# Altium schematic sheet [12] - HDMI, HDMI OUTPUT.SchDoc("[12] - HDMI, HDMI OUTPUT")
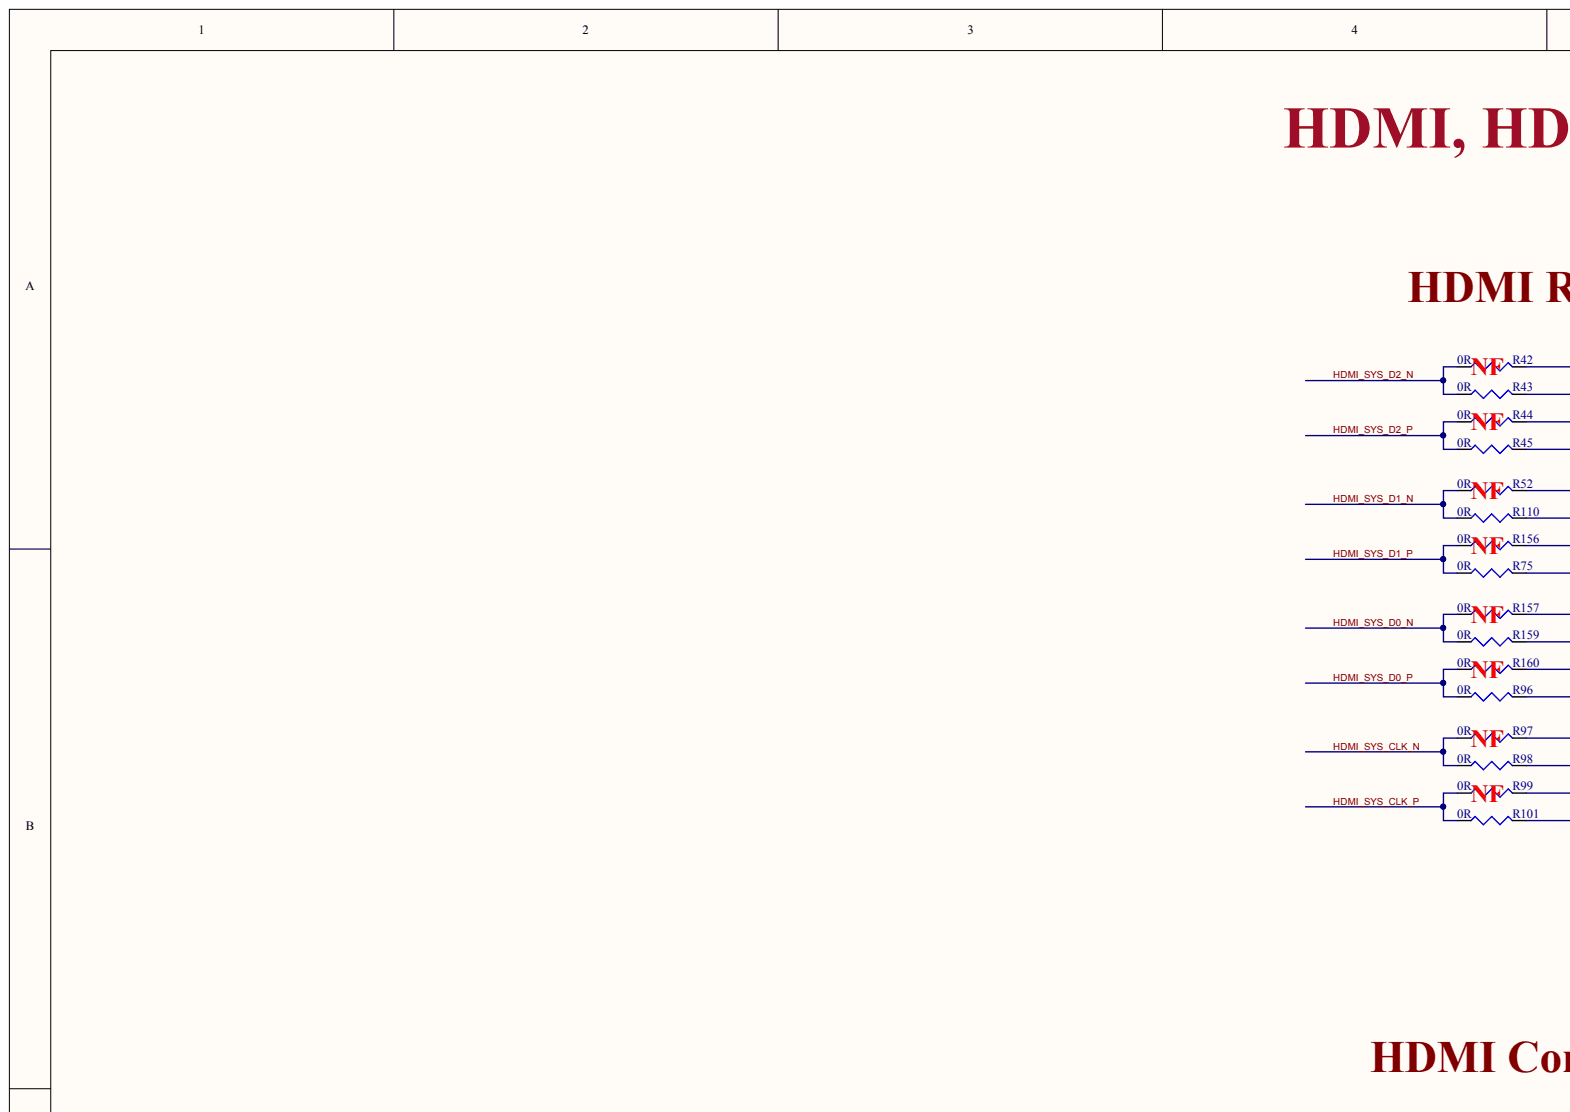
[diagram p0: [12] - HDMI, HDMI OUTPUT.SchDoc, top left]
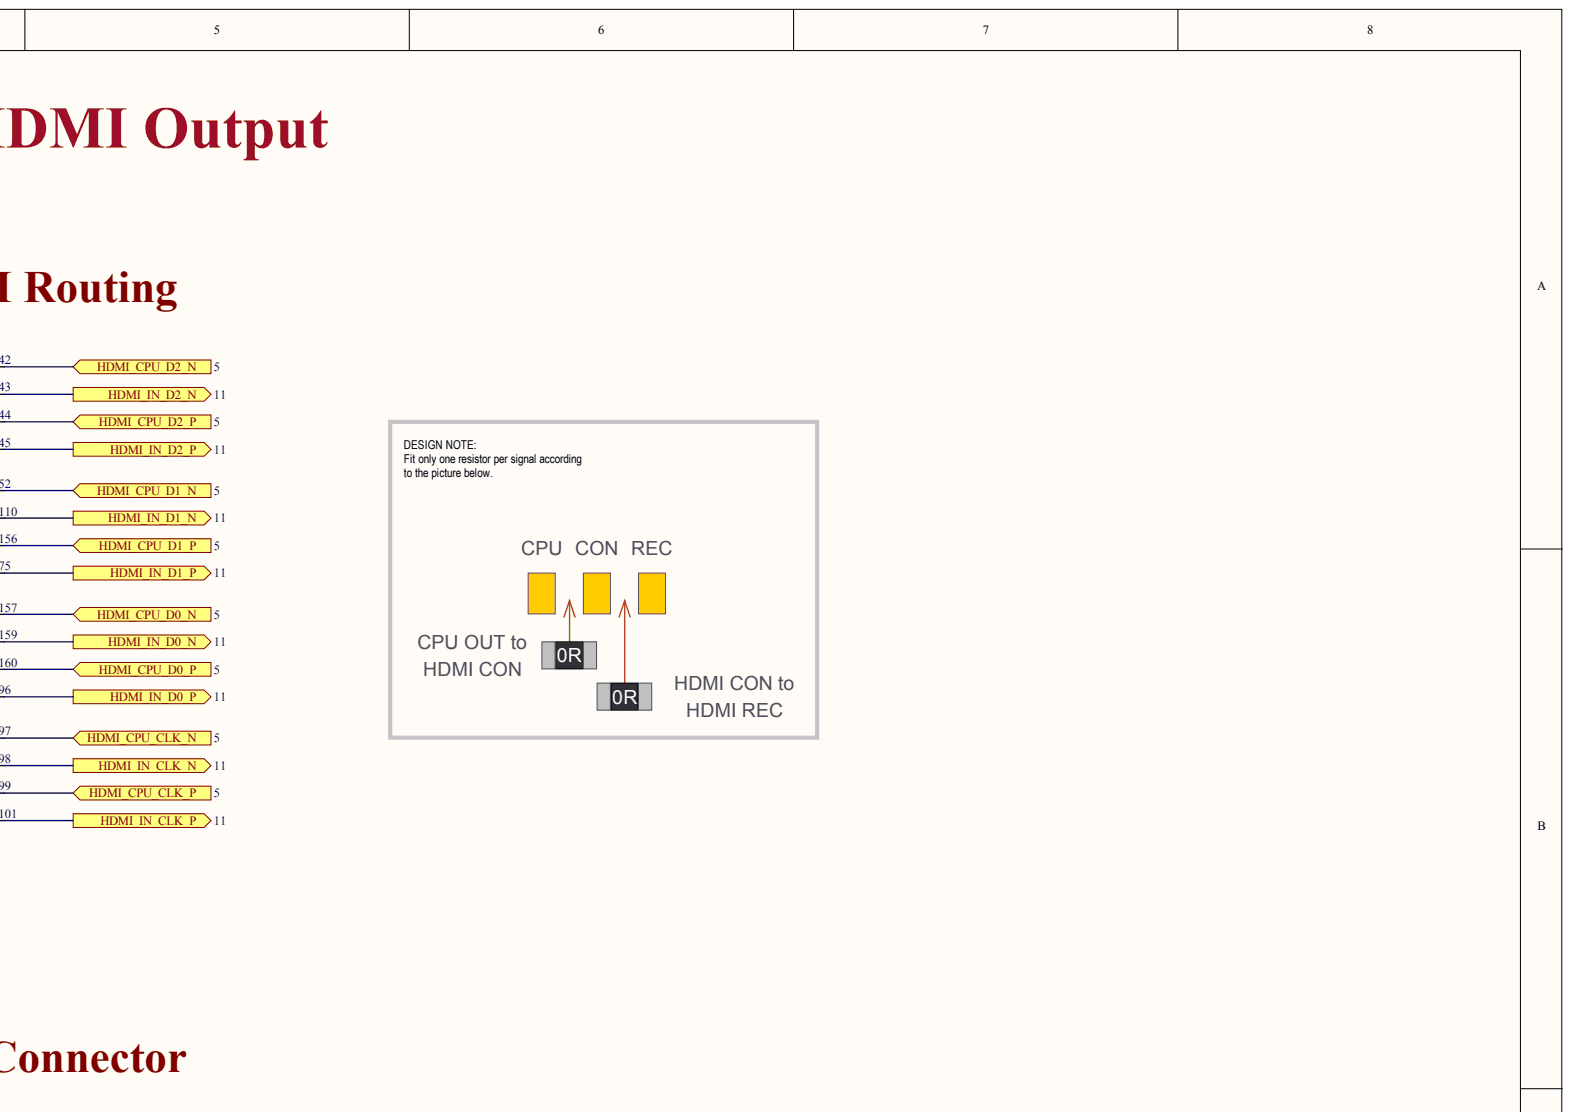
[diagram p1: [12] - HDMI, HDMI OUTPUT.SchDoc, top right]
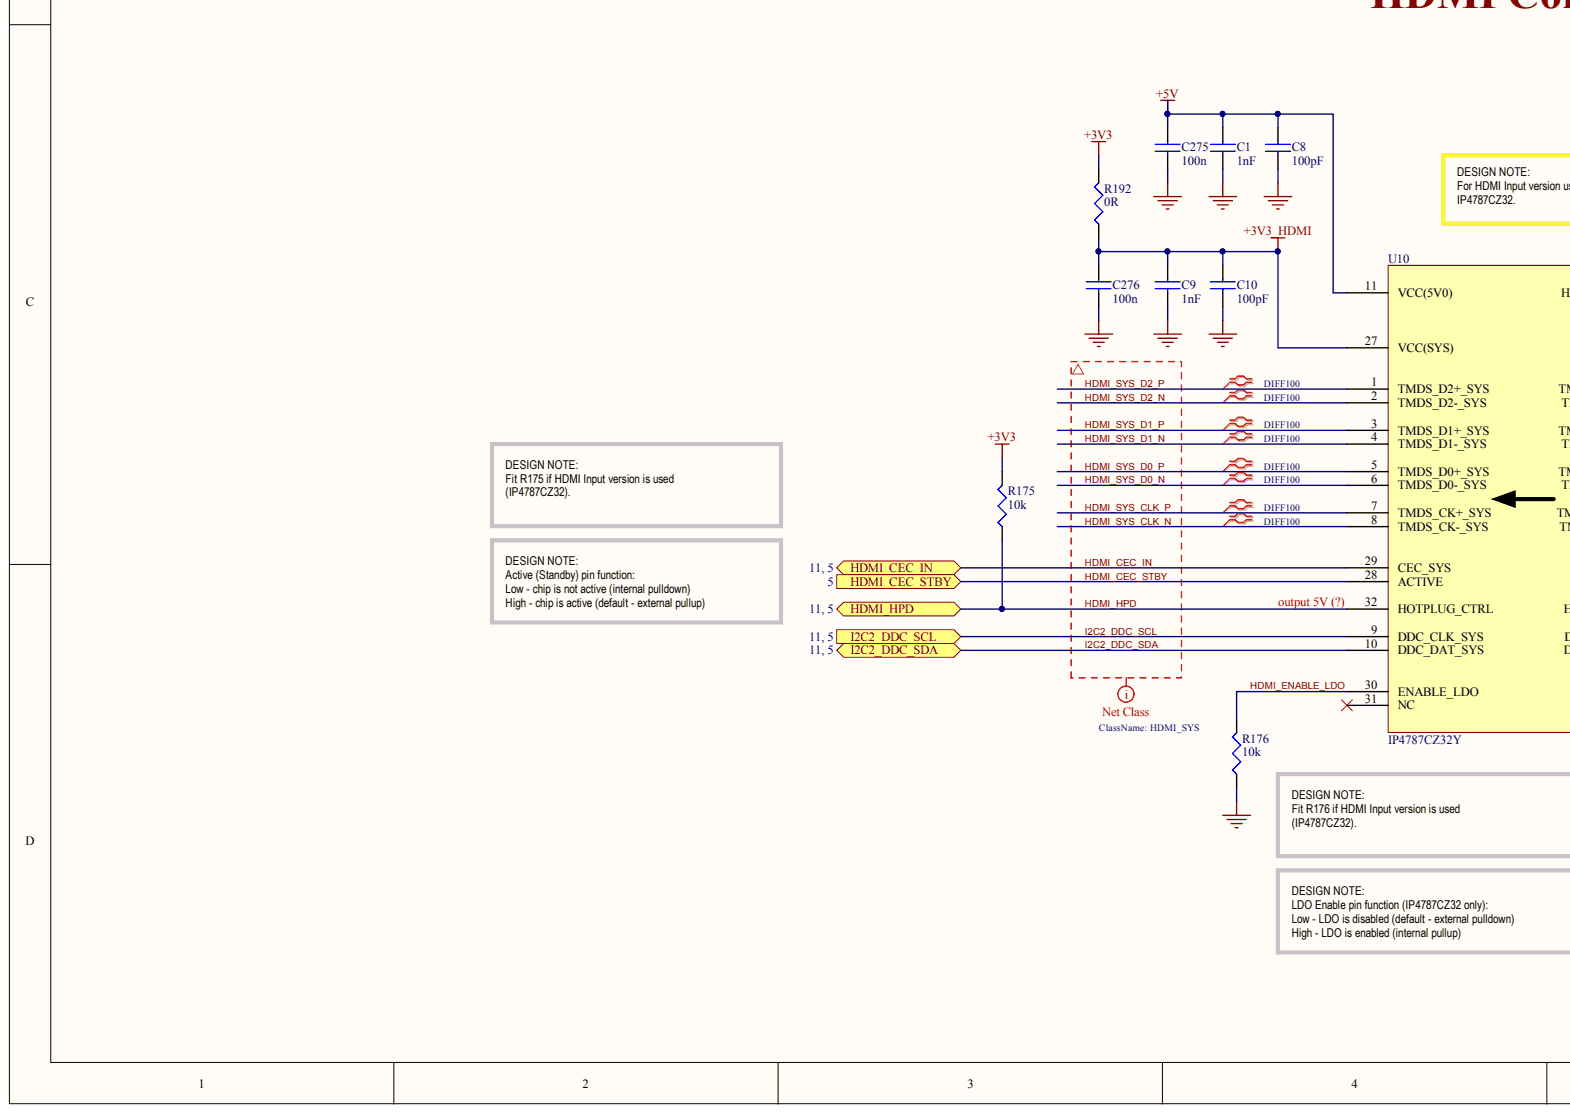
[diagram p2: [12] - HDMI, HDMI OUTPUT.SchDoc, bottom left]
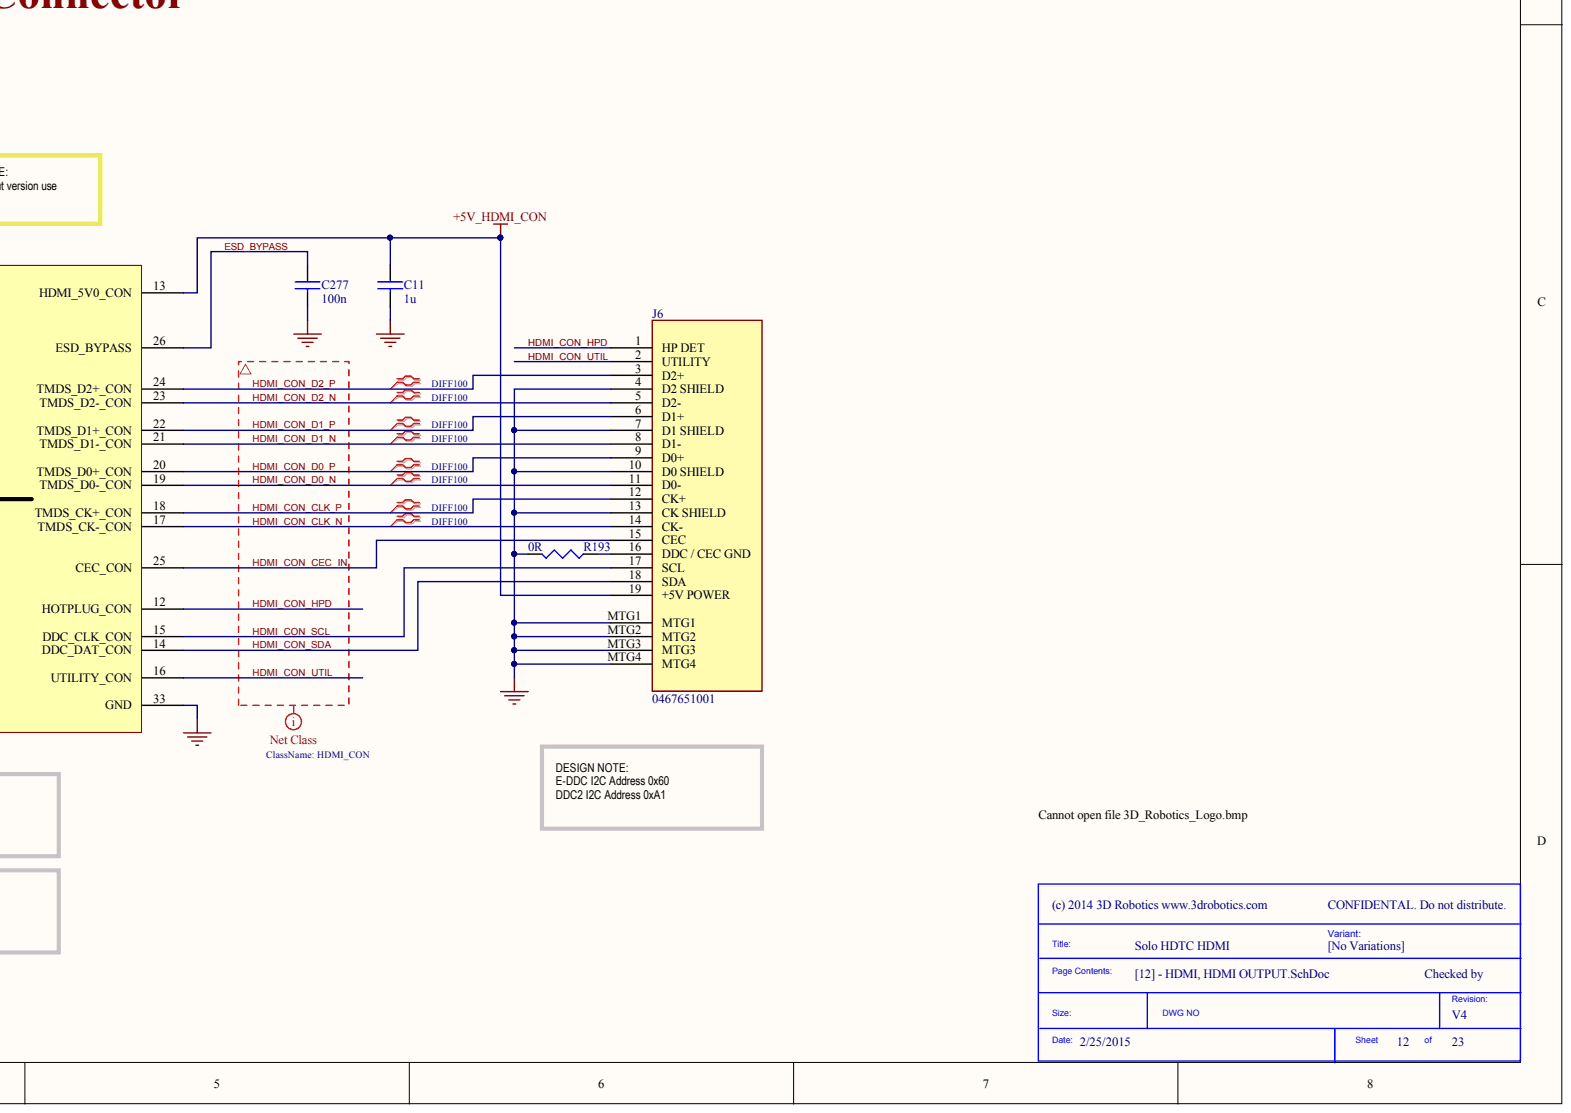
[diagram p3: [12] - HDMI, HDMI OUTPUT.SchDoc, bottom right]

## Parts
C1  1nF
C8  100pF
C9  1nF
C10  100pF
C11  1u
C275  100n
C276  100n
C277  100n
J6  0467651001
R42  0R
R43  0R
R44  0R
R45  0R
R52  0R
R75  0R
R96  0R
R97  0R
R98  0R
R99  0R
R101  0R
R110  0R
R156  0R
R157  0R
R159  0R
R160  0R
R175  10k
R176  10k
R192  0R
R193  0R
U10  IP4787CZ32Y  Voltage - Supply: 5V

## Ports
HDMI_CEC_IN, HDMI_CEC_STBY, HDMI_CPU_CLK_N, HDMI_CPU_CLK_P, HDMI_CPU_D0_N, HDMI_CPU_D0_P, HDMI_CPU_D1_N, HDMI_CPU_D1_P, HDMI_CPU_D2_N, HDMI_CPU_D2_P, HDMI_HPD, HDMI_IN_CLK_N, HDMI_IN_CLK_P, HDMI_IN_D0_N, HDMI_IN_D0_P, HDMI_IN_D1_N, HDMI_IN_D1_P, HDMI_IN_D2_N, HDMI_IN_D2_P, I2C2_DDC_SCL, I2C2_DDC_SDA

## Nets
+3V3: R175.2 R192.2
+3V3_HDMI: C9.1 C10.1 C276.1 R192.1 U10.27(VCC(SYS))
+5V: C1.1 C8.1 C275.1 U10.11(VCC(5V0))
+5V_HDMI_CON: C11.1 J6.19(+5V_POWER) U10.13(HDMI_5V0_CON)
GND: C1.2 C8.2 C9.2 C10.2 C11.2 C275.2 C276.2 C277.2 J6.4(D2_SHIELD) J6.7(D1_SHIELD) J6.10(D0_SHIELD) J6.13(CK_SHIELD) J6.MTG1 J6.MTG2 J6.MTG3 J6.MTG4 R176.1 R193.2 U10.33(GND)
ESD_BYPASS: C277.1 U10.26(ESD_BYPASS)
HDMI_CEC_IN: U10.29(CEC_SYS) ; port HDMI_CEC_IN
HDMI_CEC_STBY: U10.28(ACTIVE) ; port HDMI_CEC_STBY
HDMI_CON_CEC_IN: J6.15(CEC) U10.25(CEC_CON)
HDMI_CON_CLK_N: J6.14(CK-) U10.17(TMDS_CK-_CON)
HDMI_CON_CLK_P: J6.12(CK+) U10.18(TMDS_CK+_CON)
HDMI_CON_D0_N: J6.11(D0-) U10.19(TMDS_D0-_CON)
HDMI_CON_D0_P: J6.9(D0+) U10.20(TMDS_D0+_CON)
HDMI_CON_D1_N: J6.8(D1-) U10.21(TMDS_D1-_CON)
HDMI_CON_D1_P: J6.6(D1+) U10.22(TMDS_D1+_CON)
HDMI_CON_D2_N: J6.5(D2-) U10.23(TMDS_D2-_CON)
HDMI_CON_D2_P: J6.3(D2+) U10.24(TMDS_D2+_CON)
HDMI_CON_HPD: J6.1(HP_DET) U10.12(HOTPLUG_CON)
HDMI_CON_SCL: J6.17(SCL) U10.15(DDC_CLK_CON)
HDMI_CON_SDA: J6.18(SDA) U10.14(DDC_DAT_CON)
HDMI_CON_UTIL: J6.2(UTILITY) U10.16(UTILITY_CON)
HDMI_CPU_CLK_N: R97.2 ; port HDMI_CPU_CLK_N
HDMI_CPU_CLK_P: R99.2 ; port HDMI_CPU_CLK_P
HDMI_CPU_D0_N: R157.2 ; port HDMI_CPU_D0_N
HDMI_CPU_D0_P: R160.2 ; port HDMI_CPU_D0_P
HDMI_CPU_D1_N: R52.2 ; port HDMI_CPU_D1_N
HDMI_CPU_D1_P: R156.2 ; port HDMI_CPU_D1_P
HDMI_CPU_D2_N: R42.2 ; port HDMI_CPU_D2_N
HDMI_CPU_D2_P: R44.2 ; port HDMI_CPU_D2_P
HDMI_ENABLE_LDO: R176.2 U10.30(ENABLE_LDO)
HDMI_HPD: R175.1 U10.32(HOTPLUG_CTRL) ; port HDMI_HPD
HDMI_IN_CLK_N: R98.2 ; port HDMI_IN_CLK_N
HDMI_IN_CLK_P: R101.2 ; port HDMI_IN_CLK_P
HDMI_IN_D0_N: R159.2 ; port HDMI_IN_D0_N
HDMI_IN_D0_P: R96.2 ; port HDMI_IN_D0_P
HDMI_IN_D1_N: R110.2 ; port HDMI_IN_D1_N
HDMI_IN_D1_P: R75.2 ; port HDMI_IN_D1_P
HDMI_IN_D2_N: R43.2 ; port HDMI_IN_D2_N
HDMI_IN_D2_P: R45.2 ; port HDMI_IN_D2_P
HDMI_SYS_CLK_N: R97.1 R98.1 U10.8(TMDS_CK-_SYS)
HDMI_SYS_CLK_P: R99.1 R101.1 U10.7(TMDS_CK+_SYS)
HDMI_SYS_D0_N: R157.1 R159.1 U10.6(TMDS_D0-_SYS)
HDMI_SYS_D0_P: R96.1 R160.1 U10.5(TMDS_D0+_SYS)
HDMI_SYS_D1_N: R52.1 R110.1 U10.4(TMDS_D1-_SYS)
HDMI_SYS_D1_P: R75.1 R156.1 U10.3(TMDS_D1+_SYS)
HDMI_SYS_D2_N: R42.1 R43.1 U10.2(TMDS_D2-_SYS)
HDMI_SYS_D2_P: R44.1 R45.1 U10.1(TMDS_D2+_SYS)
I2C2_DDC_SCL: U10.9(DDC_CLK_SYS) ; port I2C2_DDC_SCL
I2C2_DDC_SDA: U10.10(DDC_DAT_SYS) ; port I2C2_DDC_SDA
NetJ6_16: J6.16(DDC_/_CEC_GND) R193.1
N00653:
N00654:

Not connected: U10 31(NC)

## Library data of the parts
C1 C9 = (no symbol name): Manufacturer: TDK Corporation; Manufacturer Part Number: C1005X7R1H102K; Capacitance: 1000pF; .Checked PCB: used; Voltage - Rated: 50V; Tolerance: ±10%; Temperature Coefficient: X7R; Category: Capacitors; Supplier Part Number: 445-1256-1-ND; Operating Temperature: -55°C ~ 125°C; Package / Case: 0402 (1005 Metric); Price 1k [$]: 0.00416; Value: 1nF; .Checked SCH: used; Supplier: Digikey; Supplier 1: Digi-Key; Supplier Part Number 1: 445-1256-1-ND; RoHS: RoHS Compliant; Stock 1: 648176; Pricing 1: 1=0.08, 10=0.05, 100=0.018, 250=0.013, 500=0.0096, 1000=0.0065 (USD); Mounting Type: Surface Mount, MLCC; Applications: General Purpose; Ratings: -; Size / Dimension: 0.039" L x 0.020" W (1.00mm x 0.50mm); Height - Seated (Max): -; Thickness (Max): 0.022" (0.55mm); Lead Spacing: -; Features: -; Packaging: Cut Tape (CT); Lead Style: -; ComponentLink1URL: http://www.tdk.co.jp/tefe02/e412_c.pdf; ComponentLink1Description: http://www.tdk.co.jp/tefe02/e412_c.pdf
C8 C10 = (no symbol name): Manufacturer: TDK Corporation; Manufacturer Part Number: C1005C0G1H101J; Voltage - Rated: 50V; Tolerance: ±5%; Temperature Coefficient: C0G, NP0; .Checked PCB: RF; Supplier 1: Digi-Key; Supplier Part Number 1: 445-1247-1-ND; RoHS: RoHS Compliant; Category: Capacitors; Stock 1: 298233; Pricing 1: 1=0.08, 10=0.05, 100=0.018, 250=0.013, 500=0.0096, 1000=0.0065 (USD); Capacitance: 100pF; Mounting Type: Surface Mount, MLCC; Operating Temperature: -55°C ~ 125°C; Applications: General Purpose; Ratings: -; Package / Case: 0402 (1005 Metric); Size / Dimension: 0.039" L x 0.020" W (1.00mm x 0.50mm); Height - Seated (Max): -; Thickness (Max): 0.022" (0.55mm); Lead Spacing: -; Features: -; Packaging: Cut Tape (CT); Lead Style: -; ComponentLink1URL: http://www.tdk.com/pdf/general_B11.pdf; ComponentLink1Description: http://www.tdk.com/pdf/general_B11.pdf; .Checked SCH: RF
C11 = (no symbol name): Manufacturer: TDK Corporation; Manufacturer Part Number: C1005X5R1C105K; Capacitance: 1.0µF; Value: 1uF; .Checked PCB: used; Voltage - Rated: 16V; Tolerance: ±10%; Temperature Coefficient: X5R; Category: Capacitors; Supplier Part Number: 445-4978-1-ND; Operating Temperature: -55°C ~ 85°C; Package / Case: 0402 (1005 Metric); Price 1k [$]: 0.02080; .Checked SCH: used; Supplier: Digikey; Supplier 1: Digi-Key; Supplier Part Number 1: 445-4978-1-ND; RoHS: RoHS Compliant; Stock 1: 341010; Pricing 1: 1=0.24, 10=0.16, 100=0.064, 250=0.046, 500=0.0328, 1000=0.024 (USD); Mounting Type: Surface Mount, MLCC; Applications: General Purpose; Ratings: -; Size / Dimension: 0.039" L x 0.020" W (1.00mm x 0.50mm); Height - Seated (Max): -; Thickness (Max): 0.022" (0.55mm); Lead Spacing: -; Features: -; Packaging: Cut Tape (CT); Lead Style: -; ComponentLink1URL: http://www.tdk.co.jp/tefe02/e412_c.pdf; ComponentLink1Description: http://www.tdk.co.jp/tefe02/e412_c.pdf
C275-C277 = (no symbol name): Manufacturer: TDK Corporation; Manufacturer Part Number: C1005X5R1H104K; Capacitance: 0.1µF; Value: 100nF; .Checked PCB: used; Voltage - Rated: 50V; Tolerance: ±10%; Temperature Coefficient: X5R; Category: Capacitors; Supplier Part Number: 445-5942-1-ND; Operating Temperature: -55°C ~ 85°C; Package / Case: 0402 (1005 Metric); Price 1k [$]: 0.02340; .Checked SCH: used; Supplier: Digikey; Supplier 1: Digi-Key; Supplier Part Number 1: 445-5942-1-ND; RoHS: RoHS Compliant; Stock 1: 106926; Pricing 1: 1=0.27, 10=0.18, 100=0.072, 250=0.05176, 500=0.0369, 1000=0.027 (USD); Mounting Type: Surface Mount, MLCC; Applications: General Purpose; Ratings: -; Size / Dimension: 0.039" L x 0.020" W (1.00mm x 0.50mm); Height - Seated (Max): -; Thickness (Max): 0.022" (0.55mm); Lead Spacing: -; Features: -; Packaging: Cut Tape (CT); Lead Style: -; ComponentLink1URL: http://www.tdk.co.jp/tefe02/e412_c.pdf; ComponentLink1Description: http://www.tdk.co.jp/tefe02/e412_c.pdf
J6 = (no symbol name): Manufacturer: Molex Inc; Connector Type: HDMI - Micro; Number of Contacts: 19; Gender: Receptacle; .Creator: MM; .Checked PCB: MM, paper model not checked; Supplier 1: Digi-Key; Manufacturer Part Number: 0467651001; RoHS: RoHS Compliant; Category: Connectors, Interconnects; Stock 1: 11830; Pricing 1: 1=2.66, 10=2.414, 25=2.2664, 50=2.1678, 100=2.0693, 250=1.87224, 500=1.72442 (USD); Packaging: Cut Tape (CT); Specifications: -; Mounting Type: Surface Mount, Right Angle, Horizontal; Features: Board Guide; Ingress Protection: -; ComponentLink1URL: http://www.molex.com/pdm_docs/sd/467651001_sd.pdf; ComponentLink1Description: http://www.molex.com/pdm_docs/sd/467651001_sd.pdf; Supplier Part Number 1: WM1282CT-ND; .Checked SCH: MM
R42-R45 R52 R75 R96-R99 R101 R110 R156 R157 R159 R160 R192 R193 = (no symbol name): Manufacturer: Yageo; Manufacturer Part Number: RC0402JR-070RL; Resistance (Ohms): 0.0; Power (Watts): 0.063W, 1/16W; Tolerance: Jumper; .Checked PCB: used; Category: Resistors; Package / Case: 0402 (1005 Metric); Price 1k [$]: 0.01389; Value: 0R; .Checked SCH: used; Supplier Part Number: 311-0.0JRCT-ND; Supplier: Digikey; Supplier 1: Digi-Key; Supplier Part Number 1: 311-0.0JRCT-ND; RoHS: RoHS Compliant; Stock 1: 1106330; Pricing 1: 10=0.012, 50=0.009, 100=0.0069, 250=0.00512, 500=0.0038, 1000=0.00253 (USD); Composition: Thick Film; Features: -; Temperature Coefficient: -; Size / Dimension: 0.039" L x 0.020" W (1.00mm x 0.50mm); Height: 0.016" (0.40mm); Number of Terminations: 2; Packaging: Cut Tape (CT); ComponentLink1URL: http://www.yageo.com/documents/recent/PYu-RC0402_51_RoHS_L_6.pdf; ComponentLink1Description: http://www.yageo.com/documents/recent/PYu-RC0402_51_RoHS_L_6.pdf
R175 R176 = (no symbol name): Manufacturer: Yageo; Manufacturer Part Number: RC0402FR-0710KL; Power (Watts): 0.063W, 1/16W; Tolerance: ±1%; .Checked PCB: used; Temperature Coefficient: ±100ppm/°C; Category: Resistors; Supplier Part Number: 311-10.0KLRCT-ND; Package / Case: 0402 (1005 Metric); Price 1k [$]: 0.01421; Resistance (Ohms): 10K; Value: 10k; .Checked SCH: used; Supplier: Digikey; Supplier 1: Digi-Key; Supplier Part Number 1: 311-10.0KLRCT-ND; RoHS: RoHS Compliant; Stock 1: 2916495; Pricing 1: 10=0.014, 50=0.0104, 100=0.0079, 250=0.00584, 500=0.00432, 1000=0.00288 (USD); Composition: Thick Film; Features: -; Size / Dimension: 0.039" L x 0.020" W (1.00mm x 0.50mm); Height: 0.016" (0.40mm); Number of Terminations: 2; Packaging: Cut Tape (CT); ComponentLink1URL: http://www.yageo.com/documents/recent/PYu-RC0402_51_RoHS_L_6.pdf; ComponentLink1Description: http://www.yageo.com/documents/recent/PYu-RC0402_51_RoHS_L_6.pdf
U10 = (no symbol name): Manufacturer: NXP Semiconductors; Interface: DVI, HDMI; .Creator: MM; Supplier 1: Digi-Key; Manufacturer Part Number: IP4787CZ32Y; RoHS: RoHS Compliant; Category: Integrated Circuits (ICs); Stock 1: 0; Pricing 1: 6000=0.532 (USD); Packaging: Tape & Reel (TR); Applications: Digital Video; Package / Case: 32-VFQFN Exposed Pad; Supplier Device Package: 32-HVQFN (5x5); Mounting Type: Surface Mount; ComponentLink1URL: http://www.nxp.com/documents/data_sheet/IP4787CZ32.pdf; ComponentLink1Description: http://www.nxp.com/documents/data_sheet/IP4787CZ32.pdf; Supplier Part Number 1: IP4787CZ32Y-ND; .Checked PCB: MM; .Checked SCH: MM
